# Altium schematic sheet Display.SchDoc
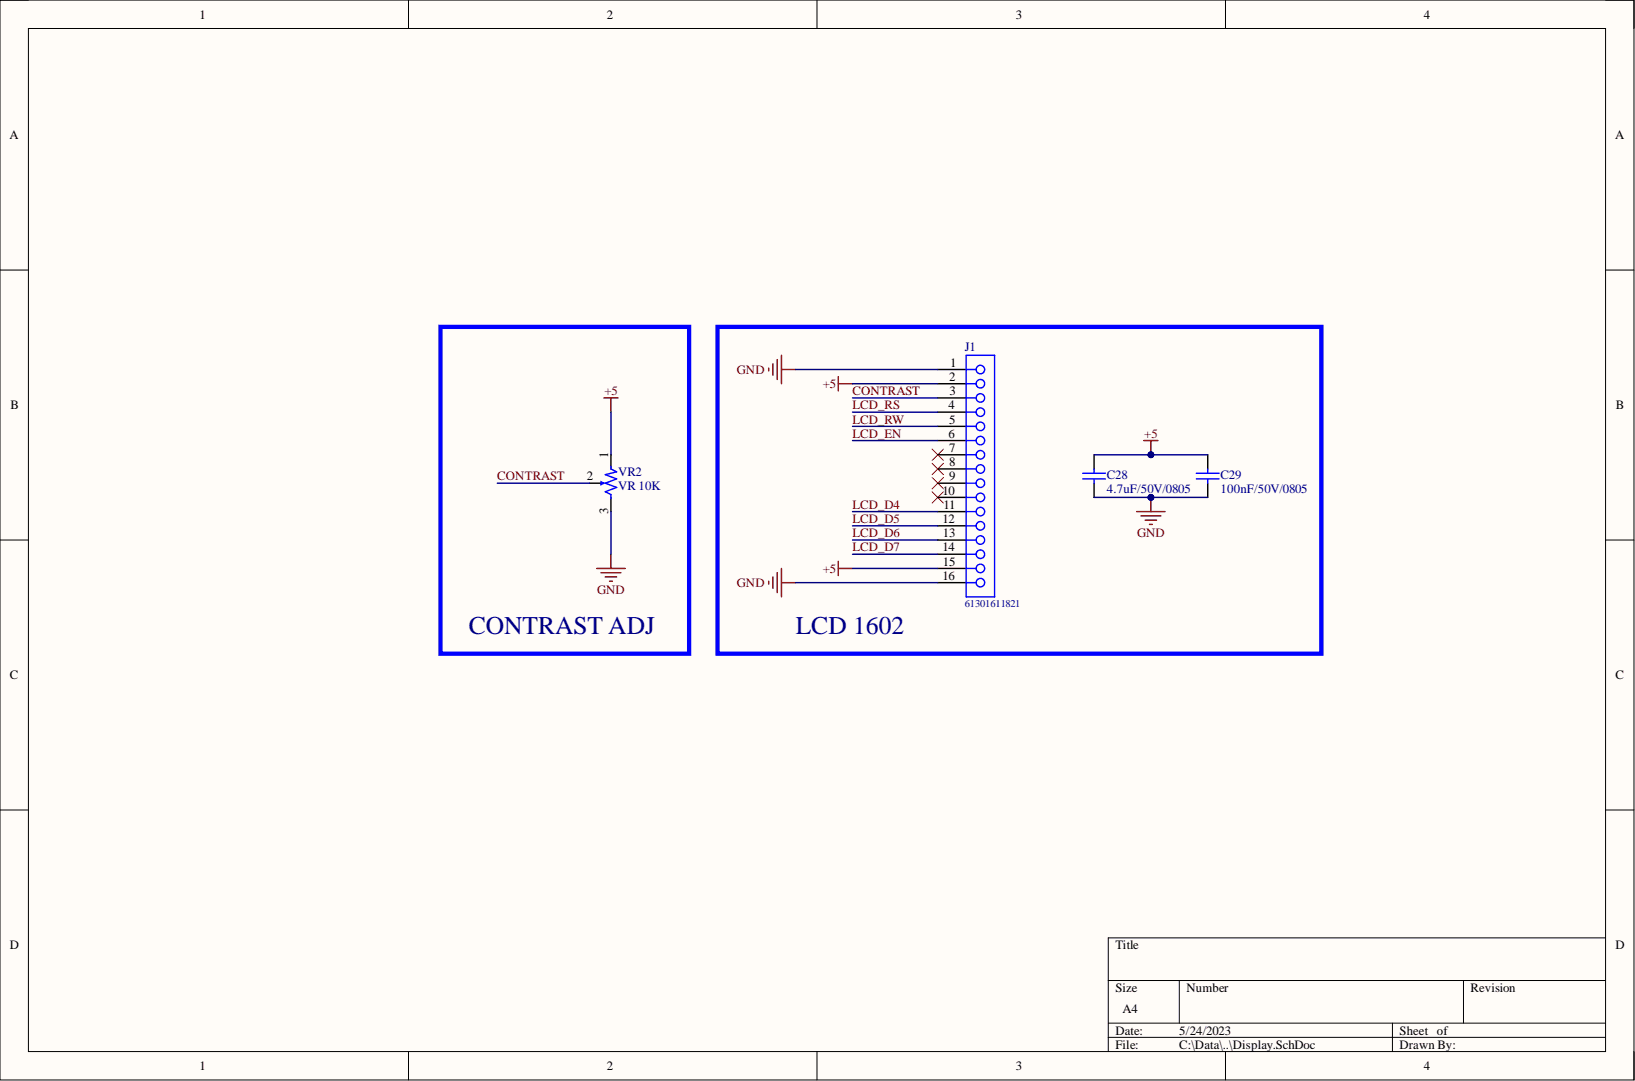
[diagram p0: Display.SchDoc]

## Parts
C28  value 4.7uF/50V/0805  [CAP-SM-0805]  (hidden comment: Cap Non-Pol SMD)
C29  value 100nF/50V/0805  [CAP-SM-0805]  (hidden comment: Cap Non-Pol SMD)
J1  61301611821  [61301611821]
VR2  10K  value VR 10K  [VARISTOR 2]

## Nets
+5: C28.1 C29.1 J1.2 J1.15 VR2.1
GND: C28.2 C29.2 J1.1 J1.16 VR2.3
CONTRAST: J1.3 VR2.2
LCD_D4: J1.11
LCD_D5: J1.12
LCD_D6: J1.13
LCD_D7: J1.14
LCD_EN: J1.6
LCD_RS: J1.4
LCD_RW: J1.5

Not connected: J1 7 8 9 10

## Library data of the parts
C28 C29 = Cap Non-Pol SMD: Capacitor; Component Kind: Standard; Library Name: PIF_Library.IntLib
J1 = 61301611821: WR-PHD Socket Header, THT, pitch 2.54mm, Single Row, Vertical, 16p; Manufacturer: Wurth Elektronik; Contact Resistance: 20mOhm; Pin Number: 16; Rated Current: 3A; Withstanding Voltage: 500VAC; Working Voltage: 250VAC; Component Kind: Standard; ComponentLink1Description: Manufacturer; ComponentLink1URL: http://www.we-online.de; ComponentLink2Description: Datasheet; ComponentLink2URL: https://www.we-online.com/catalog/datasheet/61301611821.pdf; Gender: Socket Header; Library Name: Altium_WR-PHD (rev20a).IntLib; Manufacturer Part Number: 61301611821; Mounting Technology: THT; Operating Temperature: -40 to +125degC; Orientation: Vertical; Packaging: Tray; Pitch: 2.54mm; Published Date: May 2020; Row Number: Single
VR2 = Var Resistor: Component Kind: Standard; Description: Var Resistor; Library Name: PIF_Library.IntLib
